# Altium schematic sheet TopSheet.SchDoc(TopSheet)
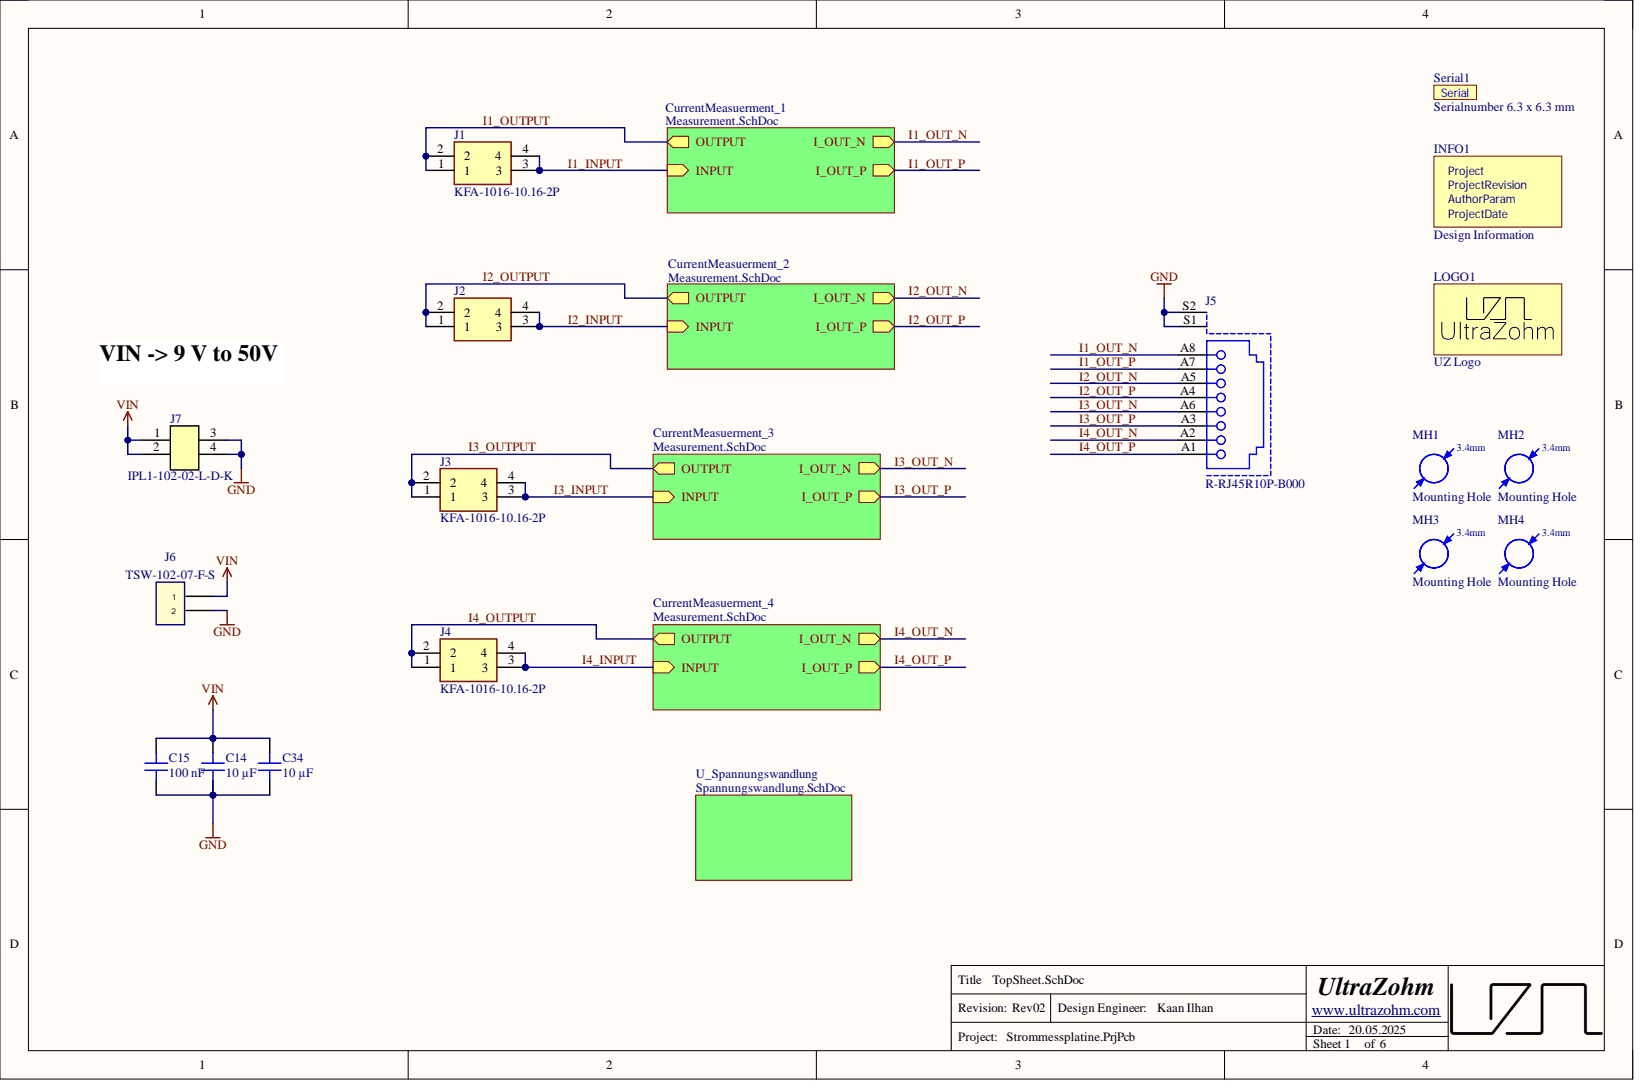
[diagram p0: TopSheet.SchDoc]

## Parts
C14  10 µF  [C_1206]
C15  100 nF  [C_0603]
C34  10 µF  [C_1206]
INFO1  Design Information  [Design_info]  (no BOM)
J1  KFA-1016-10.16-2P  [KFA-1016-10-16-2P]
J2  (no value)  [KFA-1016-10.16-2P]
J3  KFA-1016-10.16-2P  [KFA-1016-10-16-2P]
J4  KFA-1016-10.16-2P  [KFA-1016-10-16-2P]
J5  R-RJ45R10P-B000  [R-RJ45R10P-B000]
J6  TSW-102-07-F-S  [TSW-102-XX-XXX-S]
J7  IPL1-102-02-L-D-K  [IPL1-102-02-L-D-K-0]
LOGO1  UZ Logo  value Logo  Component Type: Logo  [UZ_Logo_small]  (no BOM)
MH1  Mounting Hole  [MH_3.4]  (no BOM)
MH2  Mounting Hole  [MH_3.4]  (no BOM)
MH3  Mounting Hole  [MH_3.4]  (no BOM)
MH4  Mounting Hole  [MH_3.4]  (no BOM)
Serial1  Serialnumber 6.3 x 6.3 mm  [SERIAL_6.3X6.3MM]  (no BOM)

## Nets
GND: C14.2 C15.2 C34.1 J5.S1 J5.S2 J6.2 J7.3 J7.4
VIN: C14.1 C15.1 C34.2 J6.1 J7.1 J7.2
I1_INPUT: J1.3 J1.4
I1_OUT_N: J5.A8
I1_OUT_P: J5.A7
I1_OUTPUT: J1.1 J1.2
I2_INPUT: J2.3 J2.4
I2_OUT_N: J5.A5
I2_OUT_P: J5.A4
I2_OUTPUT: J2.1 J2.2
I3_INPUT: J3.3 J3.4
I3_OUT_N: J5.A6
I3_OUT_P: J5.A3
I3_OUTPUT: J3.1 J3.2
I4_INPUT: J4.3 J4.4
I4_OUT_N: J5.A2
I4_OUT_P: J5.A1
I4_OUTPUT: J4.1 J4.2

## Library data of the parts
C14 C34 = CGA5L1X7R1H106K160AC: Capacitor 1206, 50 VDC, 10 µF, X7R; Manufacturer: TDK; Created from: MGremer; Database Table Name: Capacitors - SMD; Tolerance: 10 %; Voltage Rating: 50 VDC; Component Kind: Standard; Component Type: Capacitor 1206; ComponentLink1Description: Datasheet; ComponentLink1URL: https://product.tdk.com/info/en/catalog/datasheets/mlcc_automotive_general_en.pdf; Created On: 20/12/2020 13:54:13; Device Package: 1206; Last Updated: 27/05/2022 14:15:21; LCSC Part Number: C13585; Library Name: user.DbLib; Live Cycle Status: 1; Manufacturer Part Number: CGA5L1X7R1H106K160AC; Mounting Type: SMD; Operating Temperature: -55 - 125°C; PartID: 25; Series: CGA; Stock number: Labor SMD Container; Supplier 1: Mouser; Supplier Part Number 1: 810-CGA5L1X7R1H106K1; Type: Capacitor; Value: 10 µF
C15 = C0603C104K5RAC3121: Capacitor 0603, 50 VDC, 100nF, X7R; Manufacturer: KEMET; Created from: DHufnagel; Database Table Name: Capacitors - SMD; Tolerance: 10 %; Voltage Rating: 50 VDC; Component Kind: Standard; Component Type: Capacitor 0603; ComponentLink1Description: Datasheet; ComponentLink1URL: https://www.mouser.de/datasheet/2/212/KEM_C1023_X7R_AUTO_SMD-1093309.pdf; Created On: 11/08/2022 14:33:29; Device Package: 0603; Last Updated: 19/08/2022 08:26:58; LCSC Part Number: C696358; Library Name: user.DbLib; Live Cycle Status: 1; Manufacturer Part Number: C0603C104K5RAC3121; Mounting Type: SMD; Operating Temperature: -55 - 125°C; PartID: 99; Series: X7R; Stock number: not stored; Supplier 1: Mouser; Supplier 2: LCSC; Supplier Part Number 1: 80-C603C104K5RAC3121; Supplier Part Number 2: C696358; Type: Capacitor; Value: 100 nF
INFO1 = Design Information: Design InformationS; Manufacturer: UltraZohm; Created from: MGremer; Database Table Name: Misc; Component Type: Design Information; ComponentLink1Description: https://docs.ultrazohm.com/; ComponentLink1URL: homepage; Created On: 03.11.2021 09:04:23; Last Updated: 03.11.2021 09:28:34; Library Name: user.DbLib; Live Cycle Status: 1; Manufacturer Part Number: Design Information; PartID: 12; Supplier 1: -; Supplier Part Number 1: -; Type: Design Information; Value: Design Information
J1 J3 J4 = KFA-1016-10.16-2P: 1x2P -40℃~+105℃ 65A 300V Gray 6~26 Direct Insert 10.16mm 10 1 2 Plugin,P=10.16mm Screw Terminal Blocks ROHS; Manufacturer: Cixi Kefa Elec; Created from: KIlhan; Database Table Name: Connector; Component Kind: Standard; ComponentLink1Description: Datasheet; ComponentLink1URL: https://jlcpcb.com/api/file/downloadByFileSystemAccessId/8588895239582978049; Created On: 20/03/2025 09:15:01; Last Updated: 20/03/2025 13:02:33; LCSC Part Number: C475110; Library Name: user.DbLib; Manufacturer Part Number: KFA-1016-10.16-2P; Mounting Type: SMD; Operating Temperature: -40℃~+105℃; PartID: 56; Series: Screw Terminal Block; Supplier 1: LCSC; Supplier Part Number 1: C475110; Value: KFA-1016-10.16-2P
J2 = KFA-1016-10.16-2P: 1x2P -40+105 65A 300V Gray 626 Straight 10.16mm 10 1 2 PluginP10.16mm  Screw terminal ROHS; Manufacturer: CIXI KEFA ELECTRONICS; Database Table Name: Connector; Geometry.Height: 29mm; Component Kind: Standard; Datasheet Link: https://a3.ldycdn.com/KFA-1016-10.16-aidqlBpqKirRljSronnloloj.pdf; LCSC Part Number: C475110; Library Name: user.DbLib; Manufacturer Part Number: KFA-1016-10.16-2P
J5 = R-RJ45R10P-B000: RJ45Receptacle 1 Shielded Bend insert Without LED 0℃~+70℃ Plugin Ethernet Connectors / Modular Connectors (RJ45 RJ11) ROHS; Manufacturer: Ckmtw(Shenzhen Cankemeng); Created from: KIlhan; Component Kind: Standard; ComponentLink1Description: Datasheet; ComponentLink1URL: https://jlcpcb.com/api/file/downloadByFileSystemAccessId/8588892085580021760; Created On: 20/03/2025 09:19:07; Database Table Name: Connector; Last Updated: 20/03/2025 13:46:21; LCSC Part Number: C386758; Library Name: user.DbLib; Manufacturer Part Number: R-RJ45R10P-B000; Mounting Type: THT; Operating Temperature: 0℃~+70℃; PartID: 57; Series: RJ45; Supplier 1: LCSC; Supplier Part Number 1: C386758; Value: R-RJ45R10P-B000
J6 = TSW-102-07-F-S: Pin Header, 2.54mm, 2x1; MANUFACTURER: SAMTEC; Created from: AGeiger; Database Table Name: Connectors - Pin Header; Number of positions: 2; Component Kind: Standard; Component Type: Pin Header; ComponentLink1Description: Datasheet; ComponentLink1URL: https://suddendocs.samtec.com/catalog_english/tsw_th.pdf; Created On: 02/12/2022 15:20:24; Device Package: THT; Last Updated: 02/12/2022 15:20:30; Library Name: user.DbLib; Live Cycle Status: 1; Manufacturer Part Number: TSW-102-07-F-S; Mounting Type: THT; Number of rows: 1; Operating Temperature: -55 to +125 °C; PartID: 13; PCB DECAL: TSW-102-XX-XXX-S; Series: TSW; Stock number: not stored; Supplier 1: Mouser; Supplier Part Number 1: 200-TSW10207FS; Type: Pin Header; CREATED BY: May 18, 2016 - Wendy Xin
J7 = IPL1-102-02-L-D-K: P=2.54mm Wire To Board Connector ROHS; Manufacturer: SAMTEC; Created from: KIlhan; Database Table Name: Connectors - Wire to Board; Number of positions: 2; Component Kind: Standard; Component Type: Samtec Connector; ComponentLink1Description: Datasheet; ComponentLink1URL: https://wmsc.lcsc.com/wmsc/upload/file/pdf/v2/lcsc/2312312026_Samtec-IPL1-102-02-L-D-K_C7437440.pdf; Created On: 20/03/2025 08:58:37; Device Package: Connector; Last Updated: 20/03/2025 09:02:48; LCSC Part Number: C7437440; Library Name: user.DbLib; Live Cycle Status: 1; Manufacturer Part Number: IPL1-102-02-L-D-K; Mounting Type: THT; Number of rows: 2; Operating Temperature: -55 - +125 °C; PartID: 59; Stock number: not stored; Supplier 1: Mouser; Supplier 2: LCSC; Supplier Part Number 1: 200-IPL110202LDK; Supplier Part Number 2: C7437440; Type: Connector
LOGO1 = Logo small: Manufacturer: UltraZohm; Created from: MGremer; Database Table Name: Misc; ComponentLink1Description: https://docs.ultrazohm.com/; ComponentLink1URL: homepage; Created On: 03.11.2021 09:04:23; Description: UZ Logo; Last Updated: 03.11.2021 09:05:50; Library Name: user.DbLib; Live Cycle Status: 1; Manufacturer Part Number: Logo small; PartID: 10; Supplier 1: -; Supplier Part Number 1: -; Type: Logo; Created: Jan2021_Liegmann
MH1-MH4 = Mounting Hole: Created from: MHlatky; Database Table Name: Misc; Component Type: Mounting Hole; ComponentLink1Description: Datasheet; Created On: 26.01.2021 09:19:51; Description: Mounting Hole; Device Package: -; Last Updated: 21.03.2022 23:05:12; Library Name: user.DbLib; Live Cycle Status: 1; Manufacturer: Mounting Hole; Manufacturer Part Number: Mounting Hole; PartID: 16; Stock number: not stored; Supplier 1: Mounting Hole; Supplier Part Number 1: Mounting Hole; Type: Mounting Hole; Value: Mounting Hole
Serial1 = Serial Number: Manufacturer: UltraZohm; Created from: MGremer; Database Table Name: Misc; Value: REV; Component Type: Serial Number; ComponentLink1Description: https://docs.ultrazohm.com/; ComponentLink1URL: homepage; Created On: 03.11.2021 09:04:23; Description: Serial Number; Last Updated: 03.11.2021 09:28:34; Library Name: user.DbLib; Live Cycle Status: 1; Manufacturer Part Number: Serial Number; PartID: 11; Supplier 1: -; Supplier Part Number 1: -; Type: Serial Number; Created: 2018_09_18_AGeiger
